# Altium schematic sheet PFM_powerManagement_internalBattery.SchDoc(PFM_powerManagement_internalBattery)
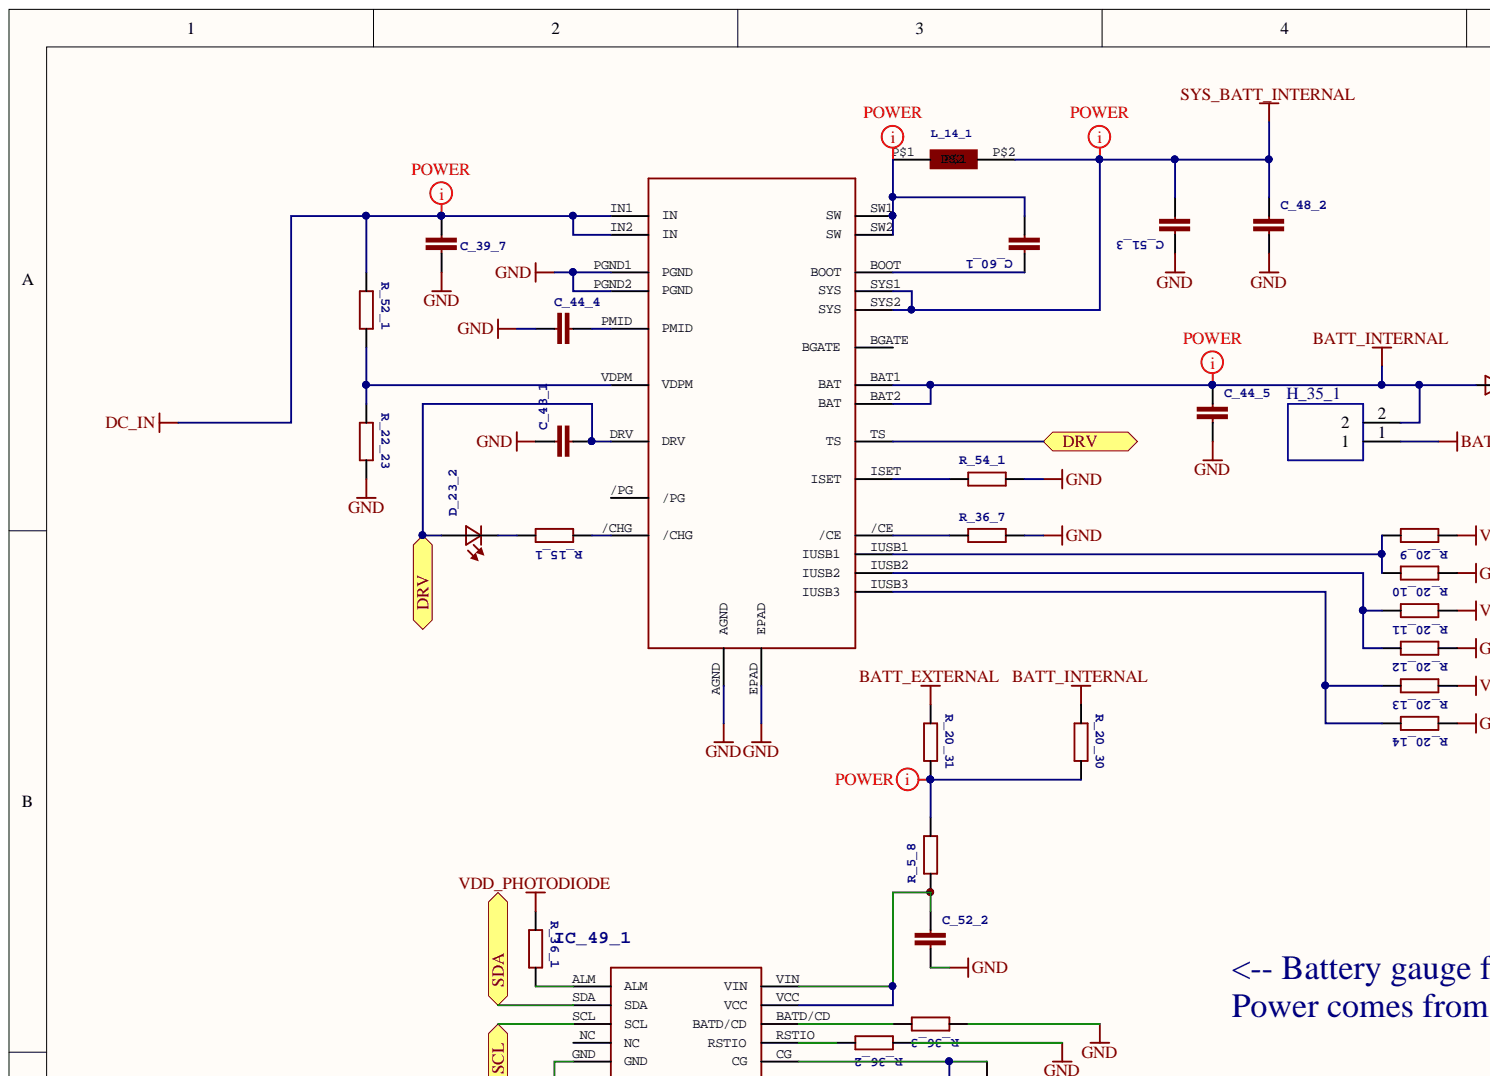
[diagram p0: PFM_powerManagement_internalBattery.SchDoc, top left]
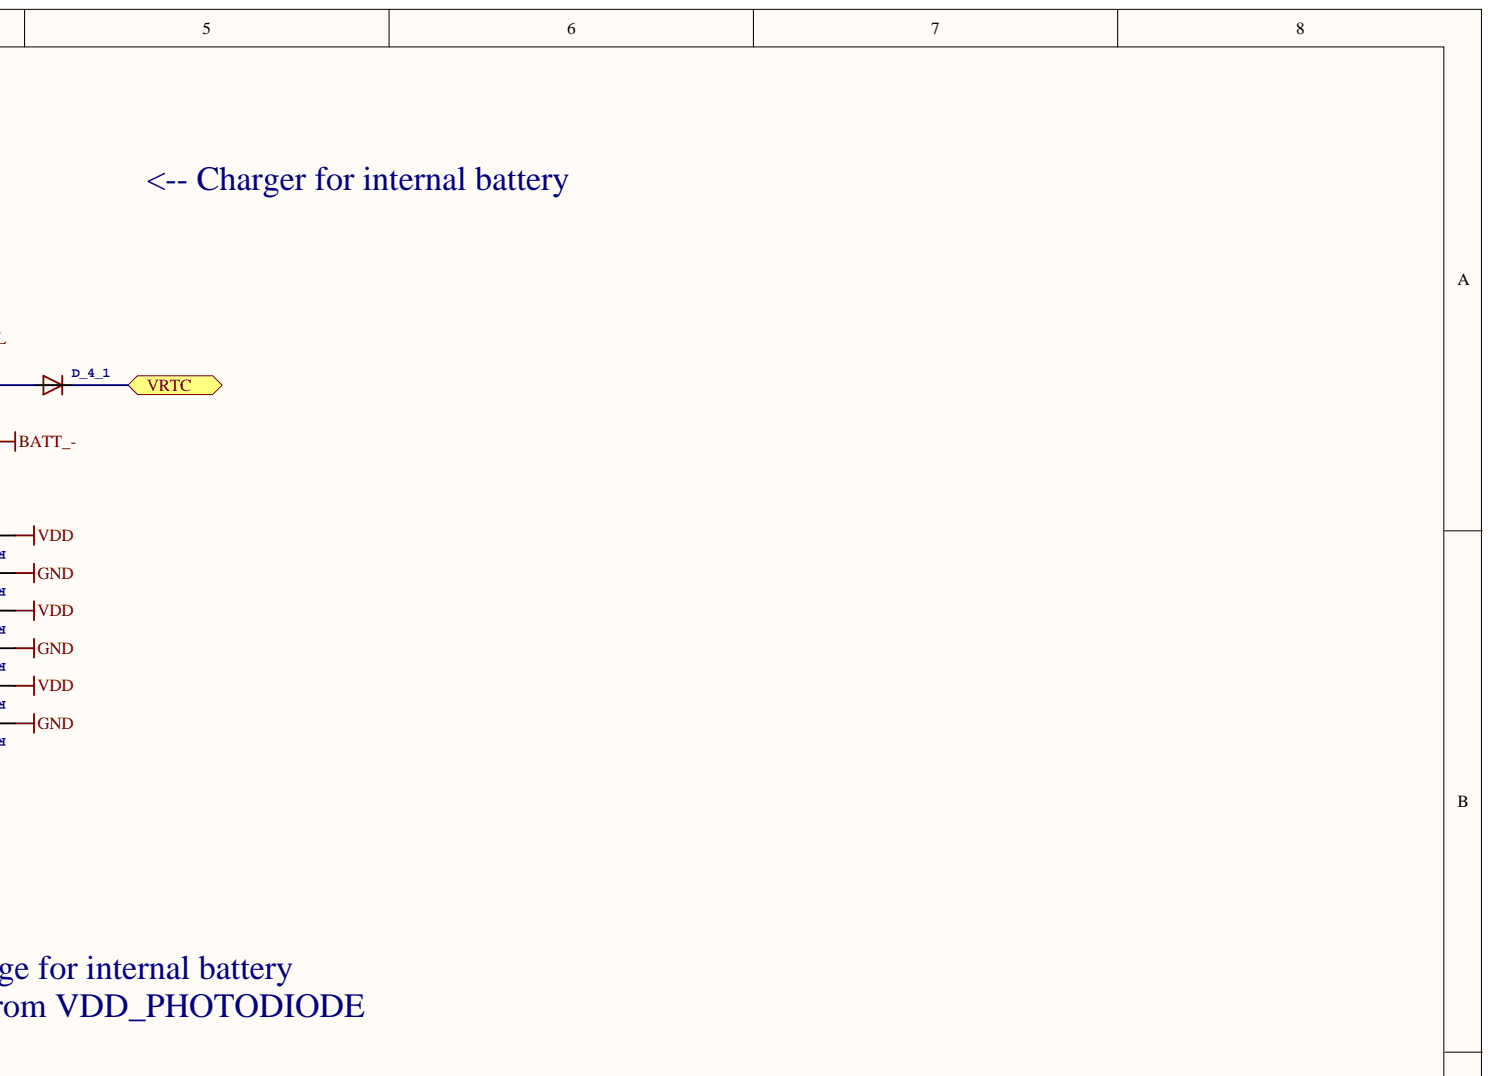
[diagram p1: PFM_powerManagement_internalBattery.SchDoc, top right]
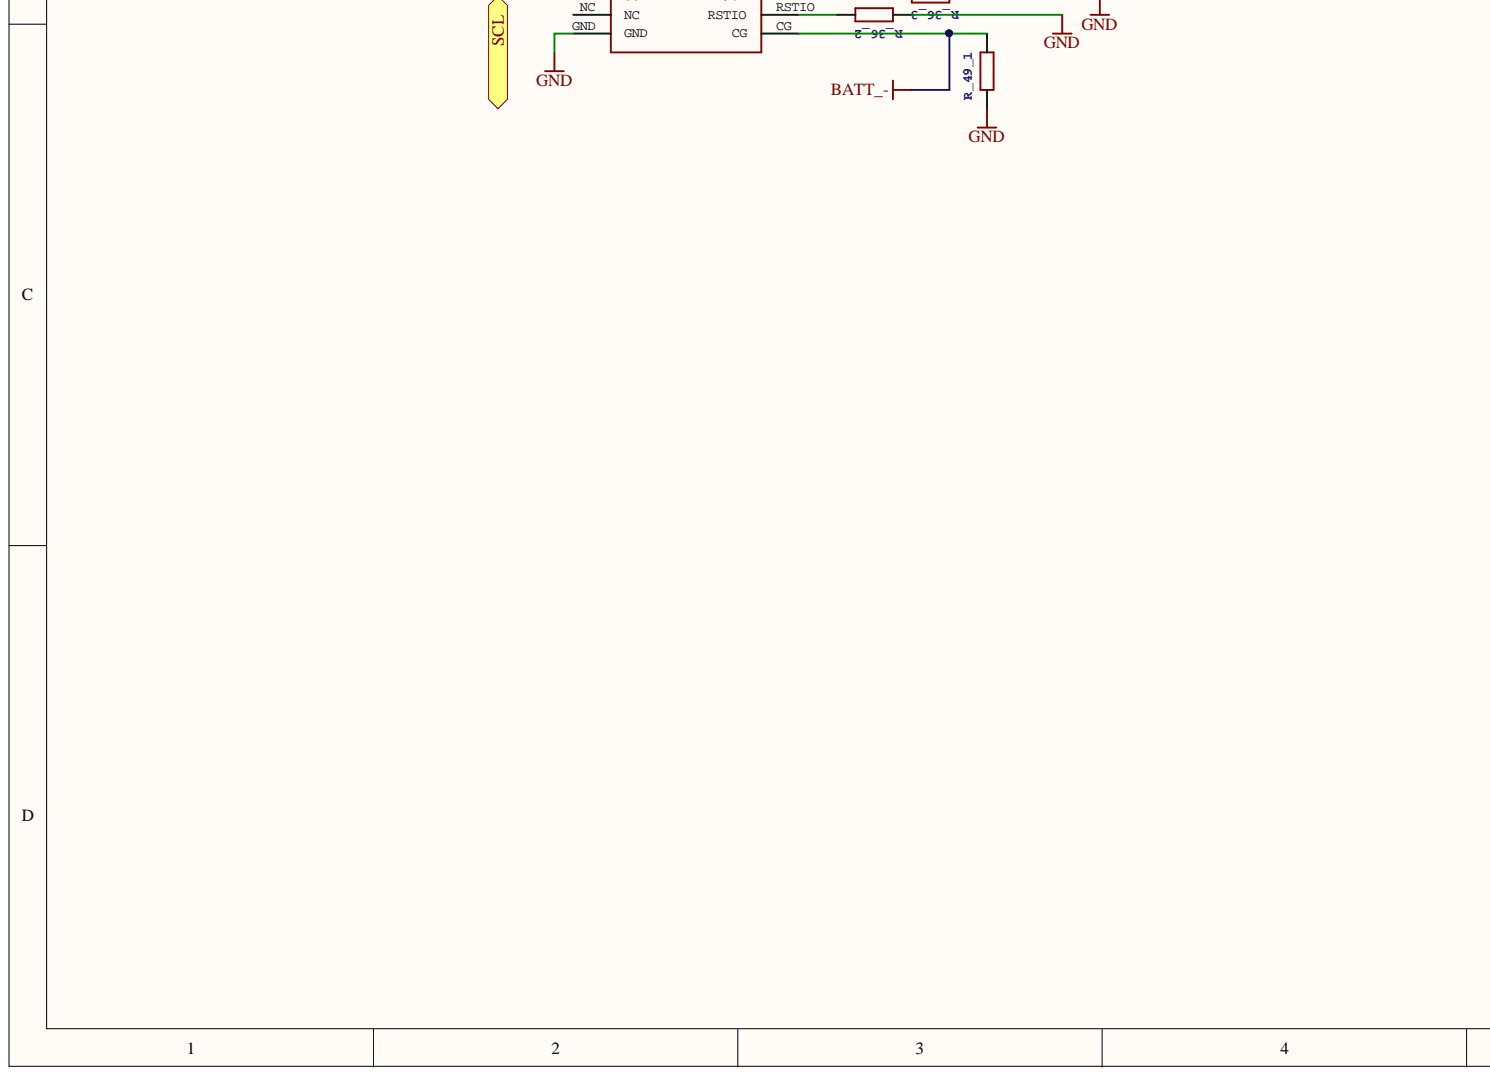
[diagram p2: PFM_powerManagement_internalBattery.SchDoc, bottom left]
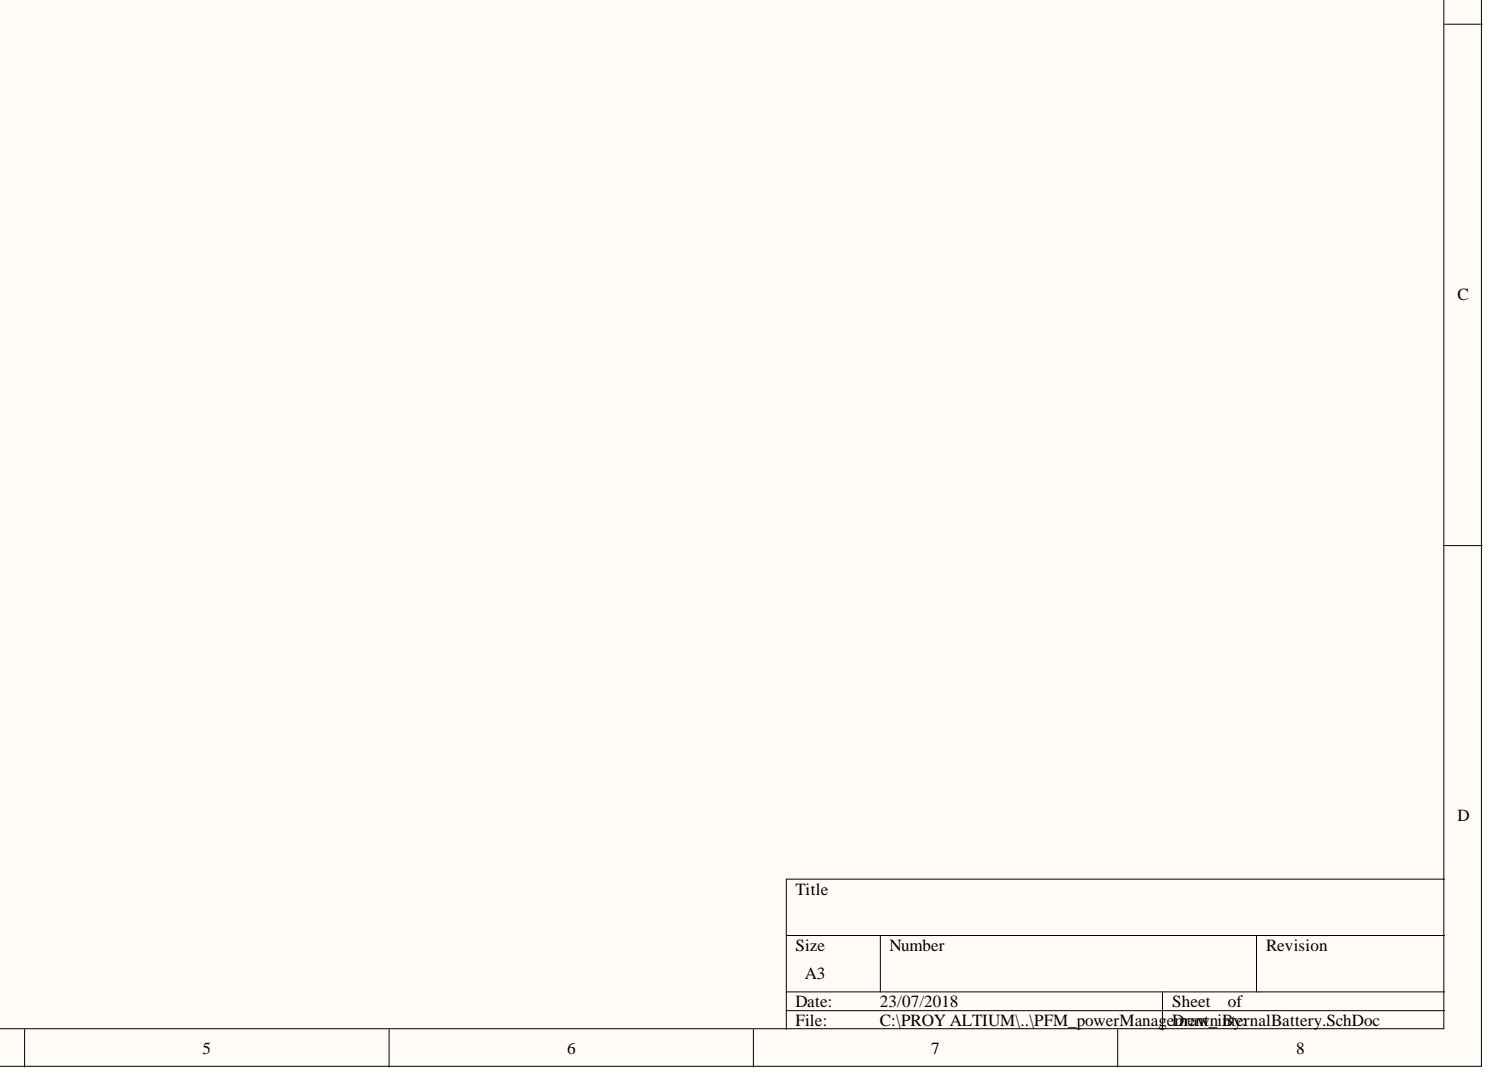
[diagram p3: PFM_powerManagement_internalBattery.SchDoc, bottom right]

## Parts
C_39_7  4.7uF;CL10A475KO8NNNC;SAMSUNG  [C0603]  (value not drawn)
C_43_1  2.2uF;C0603C225K9PACTU;Kemet  [C0603K]  (value not drawn)
C_44_4  1uF;250R14X105KV4T;JOHANSON DIELECTRICS  [C0603]  (value not drawn)
C_44_5  1uF;250R14X105KV4T;JOHANSON DIELECTRICS  [C0603]  (value not drawn)
C_48_2  47uF;C3216JB1C476M160AB;TDK Corporation  [C1206]  (value not drawn)
C_51_3  10uF;TMK107BBJ106MA-T;Taiyo Yuden  [C0603]  (value not drawn)
C_52_2  220nF;TMK107BJ224KAHT;Taiyo Yuden  [C0603]  (value not drawn)
C_60_1  0.033uF;0603YC333KAT2A;AVX  [C0603]  (value not drawn)
D_4_1  B360A-13-F;Diodes;  [DO214AC]  (value not drawn)
D_23_2  LTST-C190KGKT;Lite-On  [0603]  (value not drawn)
H_35_1  B2B-EH-A(LF)(SN);JST  [SHDR2W64P0X250_1X2_750X380X600P]  (value not drawn)
IC_49_1  STC3115AIQT;STMicroelectronics  [ST3115_PCK]  (value not drawn)
IC_52_1  BQ24266REGT;TEXAS INSTRUMENTS  [BQ24266_PCK]  (value not drawn)
L_14_1  1.5uH;SPM4012T-1R5M;TDK  [SPM4012_PCK]  (value not drawn)
R_5_8  1k;ERJ-3GEYJ102V;Panasonic  [R0603]  (value not drawn)
R_15_1  150;RC0603FR-07150RL;Yageo  [R0603]  (value not drawn)
R_20_9  0;ERJ3GEY0R00V;Panasonic;  [R0603]  (value not drawn)
R_20_10  0;ERJ3GEY0R00V;Panasonic;  [R0603]  (value not drawn)
R_20_11  0;ERJ3GEY0R00V;Panasonic;  [R0603]  (value not drawn)
R_20_12  0;ERJ3GEY0R00V;Panasonic;  [R0603]  (value not drawn)
R_20_13  0;ERJ3GEY0R00V;Panasonic;  [R0603]  (value not drawn)
R_20_14  0;ERJ3GEY0R00V;Panasonic;  [R0603]  (value not drawn)
R_20_30  0;ERJ3GEY0R00V;Panasonic;  [R0603]  (value not drawn)
R_20_31  0;ERJ3GEY0R00V;Panasonic;  [R0603]  (value not drawn)
R_22_23  10k;MCR03ERTJ103;ROHM SEMICONDUCTOR  [R0603]  (value not drawn)
R_36_1  4k7;CRCW04024K70FKED;Vishay  [R0402]  (value not drawn)
R_36_2  4k7;CRCW04024K70FKED;Vishay  [R0402]  (value not drawn)
R_36_3  4k7;CRCW04024K70FKED;Vishay  [R0402]  (value not drawn)
R_36_7  4k7;CRCW04024K70FKED;Vishay  [R0603]  (value not drawn)
R_49_1  10m;RL0603FR-070R01L;Yageo  [R0603]  (value not drawn)
R_52_1  26.7k;RC0603FR-0726K7L;Yageo;  [R0603]  (value not drawn)
R_54_1  400;PAT0603E4000BST1;VISHAY  [R0603]  (value not drawn)

## Ports
DRV, SCL, SDA, VRTC

## Nets
BATT_-: H_35_1.1 IC_49_1.CG R_49_1.2
BATT_EXTERNAL: R_20_31.1
BATT_INTERNAL: C_44_5.1 D_4_1.A H_35_1.2 IC_52_1.BAT1(BAT) IC_52_1.BAT2(BAT) R_20_30.1
DC_IN: C_39_7.2 IC_52_1.IN1(IN) IC_52_1.IN2(IN) R_52_1.1
GND: C_39_7.1 C_43_1.1 C_44_4.2 C_44_5.2 C_48_2.2 C_51_3.1 C_52_2.2 IC_49_1.GND IC_52_1.AGND IC_52_1.EPAD IC_52_1.PGND1(PGND) IC_52_1.PGND2(PGND) R_20_10.1 R_20_12.1 R_20_14.1 R_22_23.2 R_36_2.1 R_36_3.1 R_36_7.2 R_49_1.1 R_54_1.2
SYS_BATT_INTERNAL: C_48_2.1 C_51_3.2 IC_52_1.SYS1(SYS) IC_52_1.SYS2(SYS) L_14_1.P$2
VDD: R_20_9.1 R_20_11.1 R_20_13.1
VDD_PHOTODIODE: R_36_1.1
DRV: C_43_1.2 D_23_2.2 IC_52_1.DRV IC_52_1.TS ; port DRV
SCL: IC_49_1.SCL ; port SCL
SDA: IC_49_1.SDA ; port SDA
VRTC: D_4_1.C ; port VRTC
NetC_44_4_1: C_44_4.1 IC_52_1.PMID
NetC_52_2_1: C_52_2.1 IC_49_1.VCC IC_49_1.VIN R_5_8.1
NetC_60_1_1: C_60_1.1 IC_52_1.BOOT
NetC_60_1_2: C_60_1.2 IC_52_1.SW1(SW) IC_52_1.SW2(SW) L_14_1.P$1
NetD_23_2_1: D_23_2.1 R_15_1.2
NetIC_49_1_ALM: IC_49_1.ALM R_36_1.2
NetIC_49_1_BATD/CD: IC_49_1.BATD/CD R_36_3.2
NetIC_49_1_RSTIO: IC_49_1.RSTIO R_36_2.2
NetIC_52_1_/CE: IC_52_1./CE R_36_7.1
NetIC_52_1_/CHG: IC_52_1./CHG R_15_1.1
NetIC_52_1_ISET: IC_52_1.ISET R_54_1.1
NetIC_52_1_IUSB1: IC_52_1.IUSB1 R_20_9.2 R_20_10.2
NetIC_52_1_IUSB2: IC_52_1.IUSB2 R_20_11.2 R_20_12.2
NetIC_52_1_IUSB3: IC_52_1.IUSB3 R_20_13.2 R_20_14.2
NetIC_52_1_VDPM: IC_52_1.VDPM R_22_23.1 R_52_1.2
NetR_5_8_2: R_5_8.2 R_20_30.2 R_20_31.2

Not connected: IC_49_1 NC; IC_52_1 /PG BGATE

## Library data of the parts
C_39_7 = C-EUC0603: Library Name: rcl.IntLib; Description: 4.7uF;CL10A475KO8NNNC;Samsung; Component Kind: Standard
C_43_1 = C-EUC0603K: Library Name: PBv4p0.SCHLIB; Component Kind: Standard
C_44_4 C_44_5 C_51_3 C_52_2 C_60_1 = C-EUC0603: CAPACITOR, European symbol; Library Name: rcl.IntLib; Component Kind: Standard
C_48_2 = C-EUC1206: CAPACITOR, European symbol; Library Name: rcl.IntLib; Component Kind: Standard
D_4_1 = DIODE-DO214AC: Component Kind: Standard; Library Name: Easynet_Commboard_v1p1.IntLib
D_23_2 = LTST-C190GKT: https://octopart.com/ltst-c190gkt-lite-on-549821; PRICE: 0.06 USD; PACKAGE: 0603 Lite-On; MP: LTST-C190GKT; MF: Lite-On; Library Name: LTST-C190GKT.IntLib; Component Kind: Standard; AVAILABILITY: Good
H_35_1 = B2B-EH-A(LF)(SN): JST (JAPAN SOLDERLESS TERMINALS) - B2B-EH-A(LF)(SN) - CONNECTOR, HEADER, 2POS, 2.5MM, 1ROW; Geometry.Height: 6mm; Manufacturer_Part_Number: B2B-EH-A(LF)(SN); Manufacturer_Name: JST (JAPAN SOLDERLESS TERMINALS); Library Name: B2B-EH-A(LF)(SN).SchLib; Datasheet Link: http://www.farnell.com/datasheets/2057210.pdf; Component Kind: Standard; Arrow Price/Stock: https://www.arrow.com/en/products/b2b-eh-a-lf-sn/jst-manufacturing; Arrow Part Number: B2B-EH-A(LF)(SN)
IC_49_1 = STC3115_DEV: Library Name: STC3115.IntLib; Component Kind: Standard
IC_52_1 = BQ24266_DEV: Component Kind: Standard; Library Name: AgroIoT_BaseBoard_V1p0[03-18]_rev1p5.SCHLIB
L_14_1 = SPM4012_DEV: Library Name: SPM4012.IntLib; Component Kind: Standard
R_5_8 R_15_1 R_20_9-R_20_14 R_20_30 R_20_31 R_22_23 R_36_7 R_49_1 R_52_1 R_54_1 = R-EU_R0603: RESISTOR, European symbol; Library Name: PBv4p0.SCHLIB; Component Kind: Standard
R_36_1-R_36_3 = R-EU_R0402: RESISTOR, European symbol; Library Name: resistor.IntLib; Component Kind: Standard
